# Altium schematic sheet PowerSupply.SchDoc
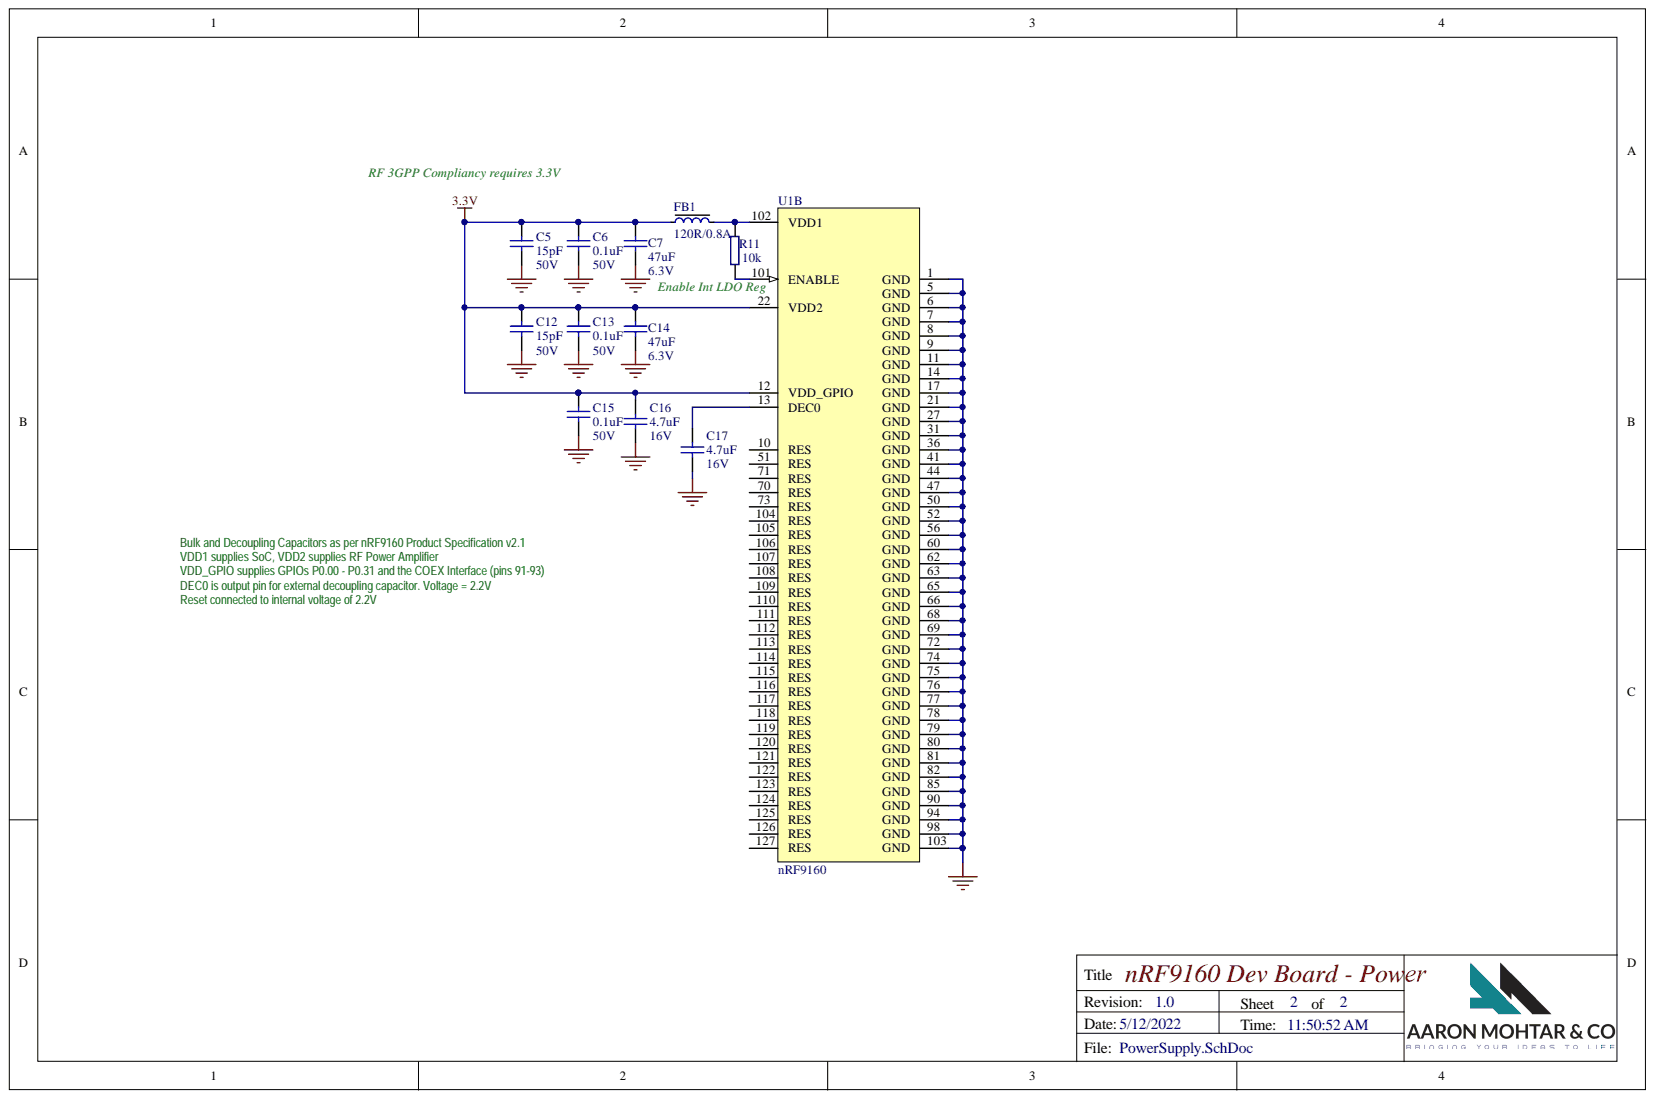
[diagram p0: PowerSupply.SchDoc]

## Parts
C5  15pF  Voltage: 50V  [CAPC0603(1608)100_N]
C6  value 0.1uF  Voltage: 50V  [CAPC0603(1608)100_N]  (hidden comment: 0.1uF 0603 50V)
C7  47uF  Voltage: 6.3V  [CAPC0805(2012)145_N]
C12  15pF  Voltage: 50V  [CAPC0603(1608)100_N]
C13  value 0.1uF  Voltage: 50V  [CAPC0603(1608)100_N]  (hidden comment: 0.1uF 0603 50V)
C14  47uF  Voltage: 6.3V  [CAPC0805(2012)145_N]
C15  value 0.1uF  Voltage: 50V  [CAPC0603(1608)100_N]  (hidden comment: 0.1uF 0603 50V)
C16  4.7uF  Voltage: 16V  [CAPC0603(1608)100_N]
C17  4.7uF  Voltage: 16V  [CAPC0603(1608)100_N]
FB1  120R/0.8A  [FP-BLM18-IPC_B]
R11  value 10k  [RESC0603(1608)_N]  (hidden comment: 10K 0603)
U1  nRF9160  [LGA50P1600X1050X104-161N]  (part B)

## Nets
3.3V: C5.2 C6.2 C7.2 C12.2 C13.2 C14.2 C15.2 C16.1 FB1.1 U1.12(VDD_GPIO) U1.22(VDD2)
GND: C5.1 C6.1 C7.1 C12.1 C13.1 C14.1 C15.1 C16.2 C17.2 U1.1(GND) U1.5(GND) U1.6(GND) U1.7(GND) U1.8(GND) U1.9(GND) U1.11(GND) U1.14(GND) U1.17(GND) U1.21(GND) U1.27(GND) U1.31(GND) U1.36(GND) U1.41(GND) U1.44(GND) U1.47(GND) U1.50(GND) U1.52(GND) U1.56(GND) U1.60(GND) U1.62(GND) U1.63(GND) U1.65(GND) U1.66(GND) U1.68(GND) U1.69(GND) U1.72(GND) U1.74(GND) U1.75(GND) U1.76(GND) U1.77(GND) U1.78(GND) U1.79(GND) U1.80(GND) U1.81(GND) U1.82(GND) U1.85(GND) U1.90(GND) U1.94(GND) U1.98(GND) U1.103(GND)
NetC17_1: C17.1 U1.13(DEC0)
NetFB1_2: FB1.2 R11.2 U1.102(VDD1)
NetR11_1: R11.1 U1.101(ENABLE)

Not connected: U1 10(RES) 51(RES) 70(RES) 71(RES) 73(RES) 104(RES) 105(RES) 106(RES) 107(RES) 108(RES) 109(RES) 110(RES) 111(RES) 112(RES) 113(RES) 114(RES) 115(RES) 116(RES) 117(RES) 118(RES) 119(RES) 120(RES) 121(RES) 122(RES) 123(RES) 124(RES) 125(RES) 126(RES) 127(RES)

## Library data of the parts
C5 C12 = CAP 15pF 50V 0603: CAP CER 15PF 50V C0G/NPO 0603; Manufacturer: Yageo; PartNumber: CC0603JRNPO9BN150; DK code: 311-1060-1-ND; Temperature coefficient: NPO; Component Kind: Standard; Operating temperature: -55°C ~ 125°C; PackageDescription: 0603; Value: 15pF; Library Name: TranspIR.SchLib
C6 C13 C15 = CAP 0.1uF 25V 0603: CAP CER 0.1UF 50V X7R 0603; Manufacturer: AVX Corporation; PartNumber: 06035C104KAT2A; DK code: 478-5052-1-ND; Component Kind: Standard; Operating temperature: -55°C ~ 125°C; PackageDescription: 0603; Temperature coefficient: X7R; Library Name: TranspIR.SchLib
C7 C14 = CAP 47uF 6.3V 0805: CAP CER 47UF 6.3V X5R 0805; Manufacturer: Samsung Electro-Mechanics; PartNumber: CL21A476MQYNNNE; DK code: 1276-1852-1-ND; Component Kind: Standard; Operating temperature: -55°C ~ 85°C; PackageDescription: 0805; Temperature coefficient: X5R; Value: 47uF; Library Name: TranspIR.SchLib
C16 C17 = CAP 4.7uF 16V 0603: CAP CER 4.7UF 16V X5R 0603; Manufacturer: Samsung Electro-Mechanics; PartNumber: CL10A475KO8NNNC; DK code: 1276-1784-1-ND; Component Kind: Standard; Library Name: Passives.SchLib; PackageDescription: 0603; Temperature coefficient: X5R; Value: 4.7uF
FB1 = IND_FB_120_100MHz: Ferrite Bead, 120 Ohm @ 100MHz, 800mA, 180 mOhm Max; Manufacturer: Murata Electronics; PartNumber: BLM18AG121SN1D; DK code: 490-1011-1-ND; Component Kind: Standard; PackageDescription: 0603; Value: 120R/0.8A; Library Name: TranspIR.SchLib
R11 = RES 10K 0603: RES SMD 10K OHM 1% 1/10W 0603; Manufacturer: Bourns Inc.; PartNumber: CR0603-FX-1002ELF; DK code: CR0603-FX-1002ELFCT-ND; Component Kind: Standard; PackageDescription: 0603; Tolerance: 1%; Library Name: TranspIR.SchLib
U1 = nRF9160_SICA_1: Low power System-in-Package with integrated LTE-M/NB-IoT modem and GPS; Manufacturer: Nordic Semiconductor; DK code: NRF9160-SICA-R; Component Kind: Standard; PackageDescription: 161 TFLGA; PartNumber: NRF9160-SICA-R; Library Name: TranspIR.SchLib
